# Altium schematic sheet POWER.SchDoc(POWER)
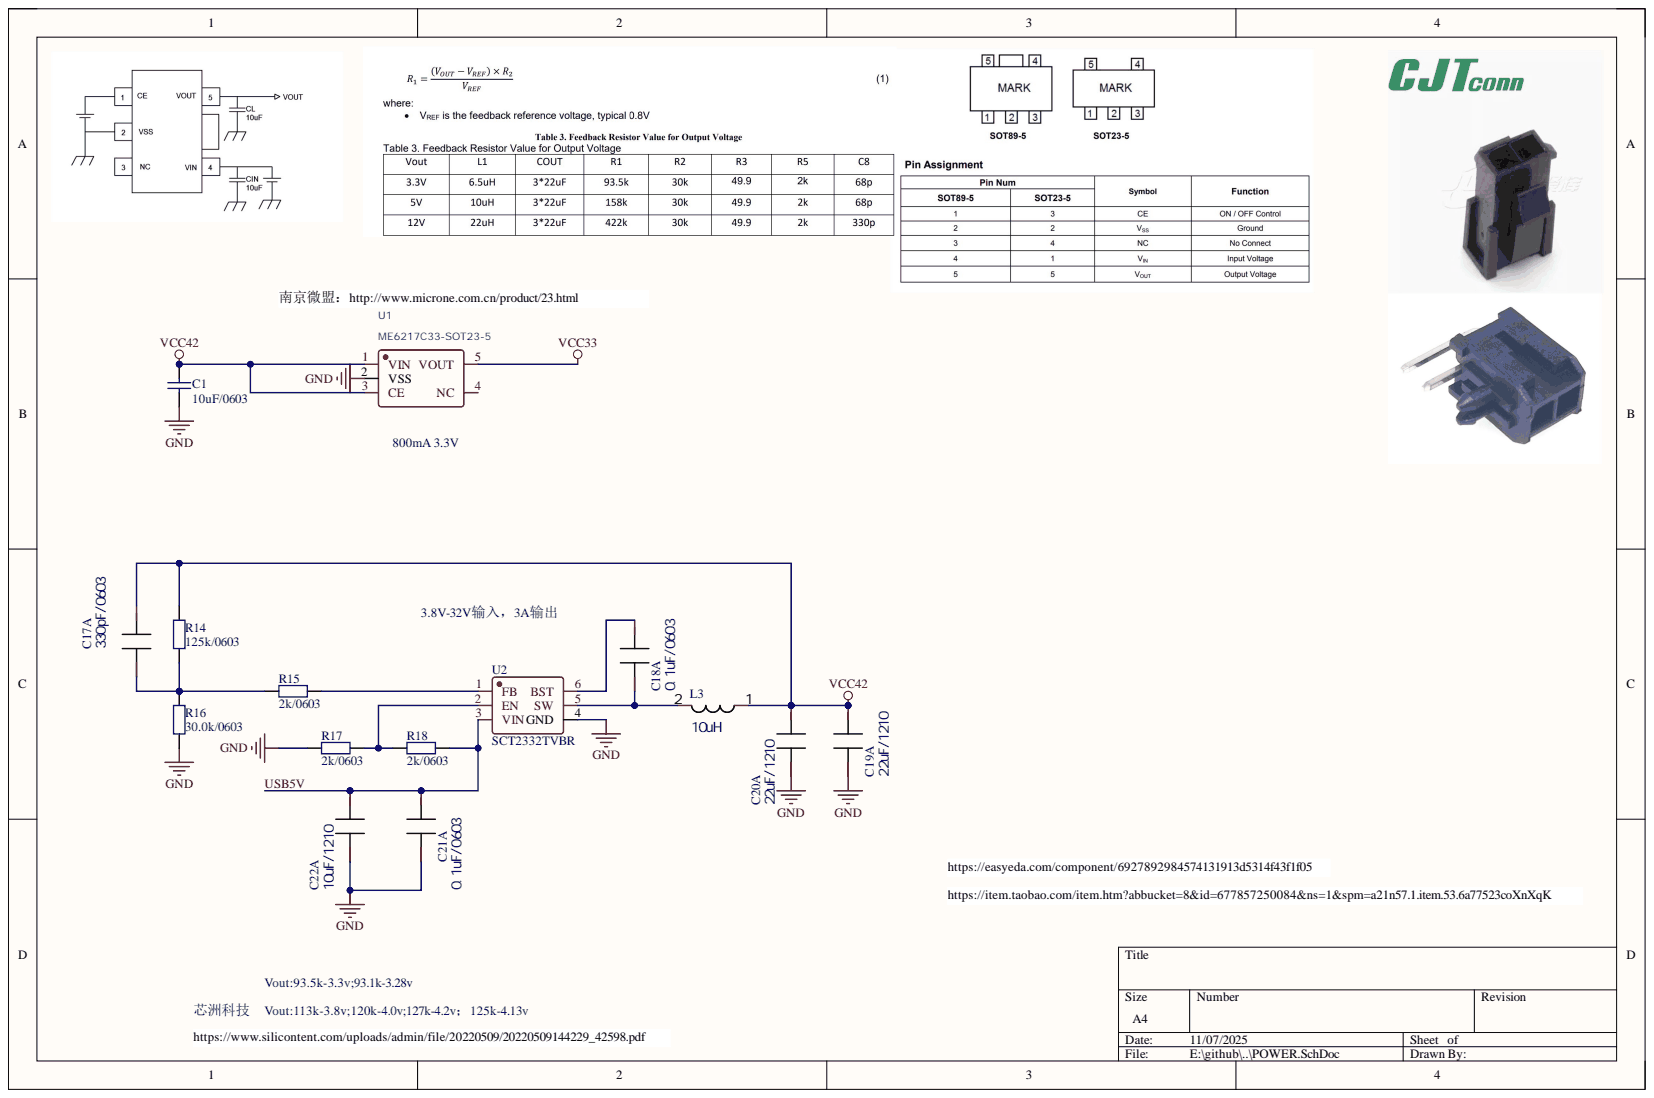
[diagram p0: POWER.SchDoc]

## Parts
C1  10uF/0603  [CAP0603]
C17  330pF/0603  [CAP0603]  (part A)
C18  0.1uF/0603  [CAP0603]  (part A)
C19  22uF/1210  [CAP0603]  (part A)
C20  22uF/1210  [CAP1210-CAPC3225X140N]  (part A)
C21  0.1uF/0603  [CAP0603]  (part A)
C22  10uF/1210  [CAP1210-CAPC3225X140N]  (part A)
L3  10uH  [IND-SMD_L4.7-W4.7-6.5uH]
R14  125k/0603  [RES0603]
R15  2k/0603  [RES0603]
R16  30.0k/0603  [RES0603]
R17  2k/0603  [RES0603]
R18  2k/0603  [RES0603]
U1  ME6217C33-SOT23-5  [ME6217C33-SOT23-5]
U2  SCT2332TVBR  [SCT2332TVBR-TSOT23-6]

## Nets
GND: C1.1 C19.2 C20.2 C21.2 C22.2 R16.2 R17.2 U1.2(VSS) U2.4(GND)
VCC33: U1.5(VOUT)
VCC42: C1.2 C17.1 C19.1 C20.1 L3.1 R14.1 U1.1(VIN) U1.3(CE)
USB5V: C21.1 C22.1 R18.1 U2.3(VIN)
NetC17_2: C17.2 R14.2 R15.2 R16.1
NetC18_1: C18.1 U2.6(BST)
NetC18_2: C18.2 L3.2 U2.5(SW)
NetR15_1: R15.1 U2.1(FB)
NetR17_1: R17.1 R18.2 U2.2(EN)

Not connected: U1 4(NC)

## Library data of the parts
C1 = Cap Semi: Capacitor (Semiconductor SIM Model); Value: 10uf; Component Kind: Standard; LatestRevisionDate: 29-May-2009; LatestRevisionNote: IPC-7351 Footprint Added.; Library Name: Miscellaneous Devices.IntLib; PackageDescription: Chip Capacitor; PackageReference: C1206; Published: 8-Jun-2000; Signal Integrity: Cap Semi; Simulation: CAP; Publisher: Altium Limited
C17 = CAP0603: SURFACE MOUNT CAPACITOR 0.031 X 0.061 INCHES; Manufacturer #1: IPC SM-782 STD.; Add into BOM: yes; Origin Footprint: 0603; Sim_Analog_Order: Model$; Value: 330pF/0603/25V; Component Kind: Standard; Convert to PCB: yes; Device: CAP0603; Name: CAP0603; OldName: CAP0603; PCB DECAL: 0603; Symbol: CAP0603
C18 C19 C21 = CAP0603: SURFACE MOUNT CAPACITOR 0.031 X 0.061 INCHES; Manufacturer #1: IPC SM-782 STD.; Add into BOM: yes; Origin Footprint: 0603; Sim_Analog_Order: Model$; Value: 0.1uF/0603/50V; Component Kind: Standard; Convert to PCB: yes; Device: CAP0603; Name: CAP0603; OldName: CAP0603; PCB DECAL: 0603; Symbol: CAP0603
C20 = CAP0603: SURFACE MOUNT CAPACITOR 0.031 X 0.061 INCHES; Manufacturer #1: IPC SM-782 STD.; Add into BOM: yes; Origin Footprint: 0603; Sim_Analog_Order: Model$; Value: 22uF/1210/35V; Component Kind: Standard; Convert to PCB: yes; Device: CAP0603; Name: CAP0603; OldName: CAP0603; PCB DECAL: 0603; Symbol: CAP0603
C22 = CAP0603: SURFACE MOUNT CAPACITOR 0.031 X 0.061 INCHES; Manufacturer #1: IPC SM-782 STD.; Add into BOM: yes; Origin Footprint: 0603; Sim_Analog_Order: Model$; Value: 10uF/1210/35V; Component Kind: Standard; Convert to PCB: yes; Device: CAP0603; Name: CAP0603; OldName: CAP0603; PCB DECAL: 0603; Symbol: CAP0603
L3 = IND-MOLDED: 0503贴片电感; Add into BOM: yes; Description" MOLDED INDUCTOR, 0_5: PIN SPACING; Origin Footprint: L_0650; Sim_Analog_Order: Model$; Sim_Analog_Prefix: L; Component Kind: Standard; Convert to PCB: yes; Device: IND-MOLDED; Name: IND-MOLDED; OldName: IND-MOLDED; PCB DECAL: L_0650; Symbol: IND-MOLDED; Value: 10uH
R14-R18 = Res2: Resistor; Component Kind: Standard; LatestRevisionDate: 17-Jul-2002; LatestRevisionNote: Re-released for DXP Platform.; Library Name: Miscellaneous Devices.IntLib; PackageDescription: Axial Device, Thru-Hole; 2 Leads; 0.4 in Pin Spacing; PackageReference: AXIAL-0.4; Published: 8-Jun-2000; Signal Integrity: Res; Simulation: RESISTOR; Publisher: Altium Limited
U1 = ME6217C33M5G: 4.2v-3.3v LDO; Component Kind: Standard
U2 = SCT2332TVBR: 3.8V-32V Vin, 3A Synchronous Step-down DCDC Converter; Component Kind: Standard; Library Name: ChargingPile.SchLib
